# Altium schematic sheet FreqIN.SchDoc(FreqIN)
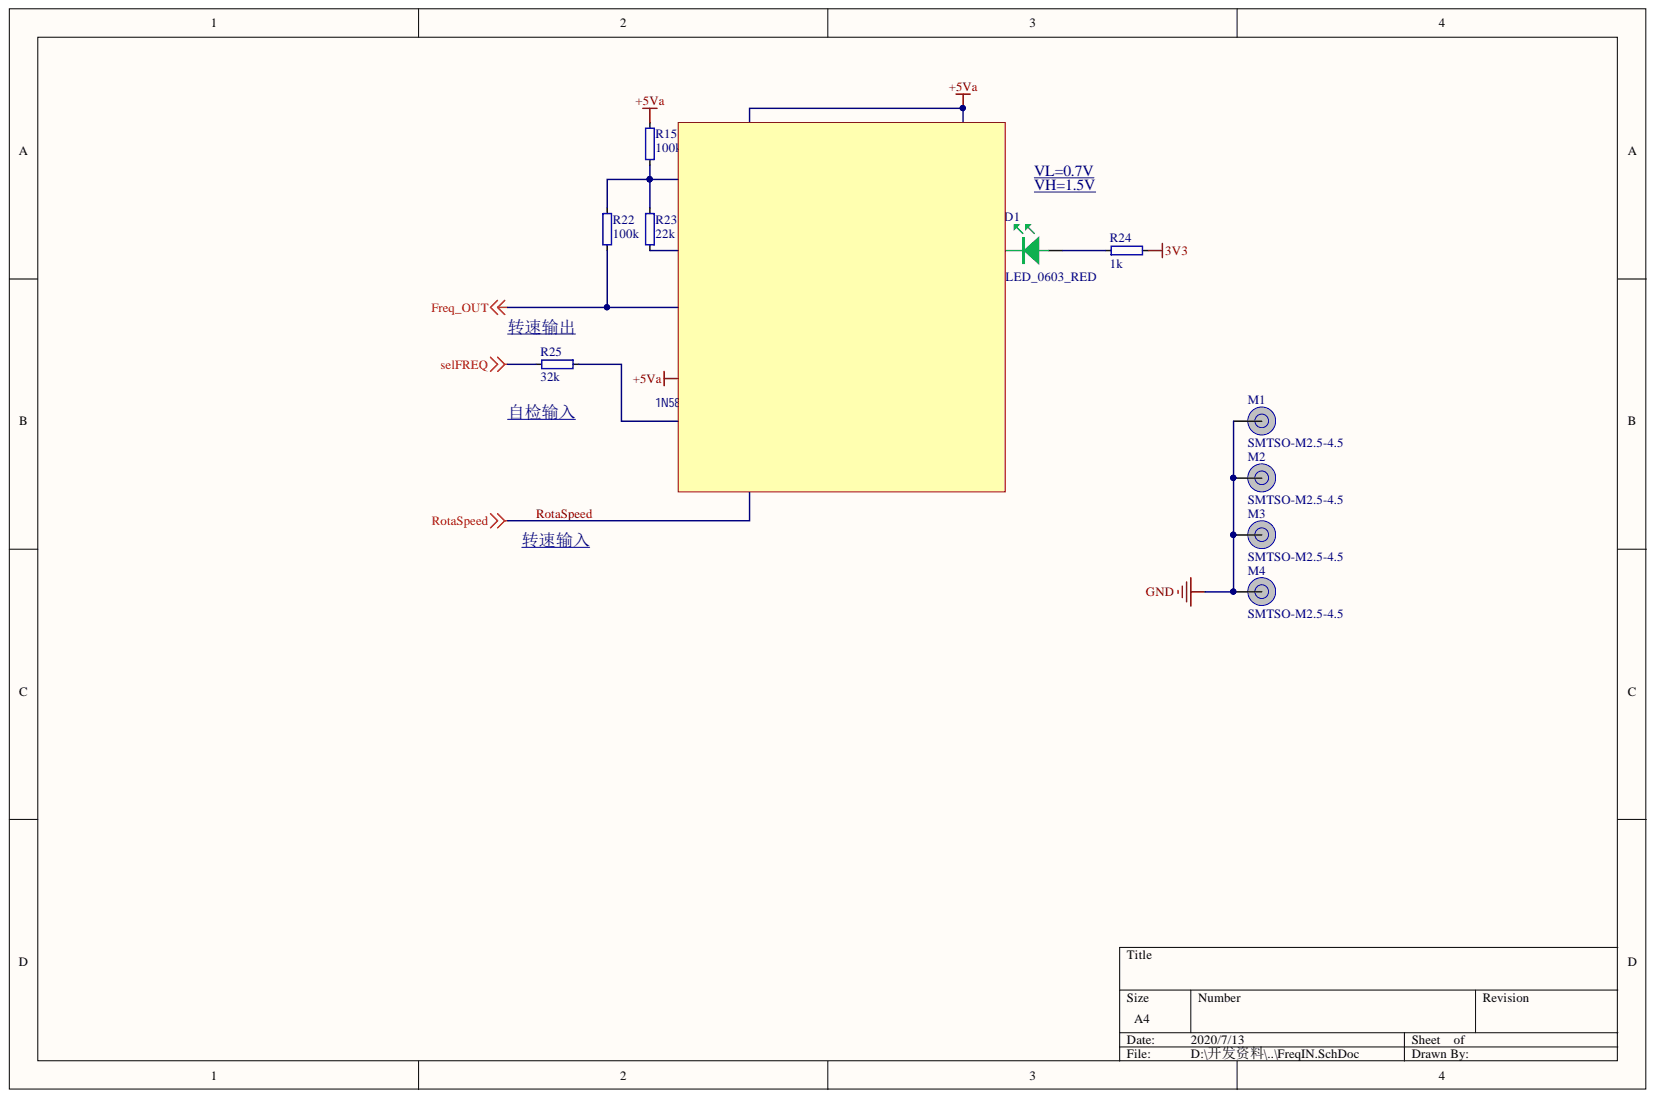
[diagram p0: FreqIN.SchDoc]

## Parts
C50  47pF  [0402_C]
C56  300pF  [0402_C]
D1  LED_0603_RED  [0603_LED_S1]
D2  1N5819 SOD323  [SOD-323]
D5  1N5819 SOD323  [SOD-323]
M1  SMTSO-M2.5-4.5  [SMTSO-M3-4]
M2  SMTSO-M2.5-4.5  [SMTSO-M3-4]
M3  SMTSO-M2.5-4.5  [SMTSO-M3-4]
M4  SMTSO-M2.5-4.5  [SMTSO-M3-4]
R15  100k  [0402]
R16  3.3M  [0402]
R22  100k  [0402]
R23  22k  [0402]
R24  1k  [0402]
R25  32k  [0402]
R26  100k  [0402]
U9  LMV331IDCKR  [SC-70-5_L2.1-W1.3-P0.65-LS2.1-BL]

## Nets
3V3: R24.1
+5VA: D2.C R15.1 R16.1 U9.5(VCC+)
FREQ_OUT: D1.K R22.1 U9.4(OUT)
GND: C50.2 C56.2 D5.A M1.1 M2.1 M3.1 M4.1 R23.2 U9.2(GND)
SELFREQ: R25.1
ROTASPEED: R26.2
NetC50_1: C50.1 R15.2 R22.2 R23.1 U9.1(IN1+)
NetC56_1: C56.1 D2.A D5.C R16.2 R25.2 R26.1 U9.3(IN1-)
NetD1_A: D1.A R24.2

## Library data of the parts
C50 = CAP: Capacitor; Component Kind: Standard
C56 = CAP_100N_25V_0402_10%_X5R: 0.1uF; MANUFACTURER: TAIYO YUDEN; PART: TMK105BJ104KV-F; Component Kind: Standard; FARNELL: 1611916; Library Name: Arbury_C.SchLib
D1 = LED_0603_GREEN: MANUFACTURER: KINGBRIGHT; PART: KP-3216CGCK; Component Kind: Standard; Description: LED_0603_GREEN; FARNELL: 2290333; Library Name: Arbury_SCH.SchLib
D2 D5 = DIO-SCH: Diode schottky; Component Kind: Standard; Library Name: Diodes_cn.SCHLIB
M1-M4 = SMTSO-M3-4: Component Kind: Standard; Library Name: Schlib1.SchLib
R15 R16 R22-R26 = R0402-12k: Resistor, ?%, 0.063W; Artikkelnr Noca: 27049099; Database Table Name: Resistors$; Note: Chip Res 1%; Value: 12k; Component Kind: Standard; LatestRevisionDate: 2009-11-23; Library Name: Nordic Passive DB.DbLib; Part Number: R0402-12k; Pin Count: 2; Published: 2009-05-08; Supplier: Farnell; Supplier part number: 173-8865; Publisher: Nordic Semiconductor
U9 = LMV331IDCKR: BOM_Manufacturer: TI; SMT Type: Extend; BOM_LCSC Assembly: Yes; BOM_Manufacturer Part: LMV331IDCKR; BOM_Supplier: LCSC; BOM_Supplier Part: C7976; Component Kind: Standard; image: //image.lceda.cn/szlcsc/C7976.jpg; spicePre: U; spiceSymbolName: LMV331IDCKR
